# Altium schematic sheet HIGHSPEED_v0_SF2-POWER.SchDoc
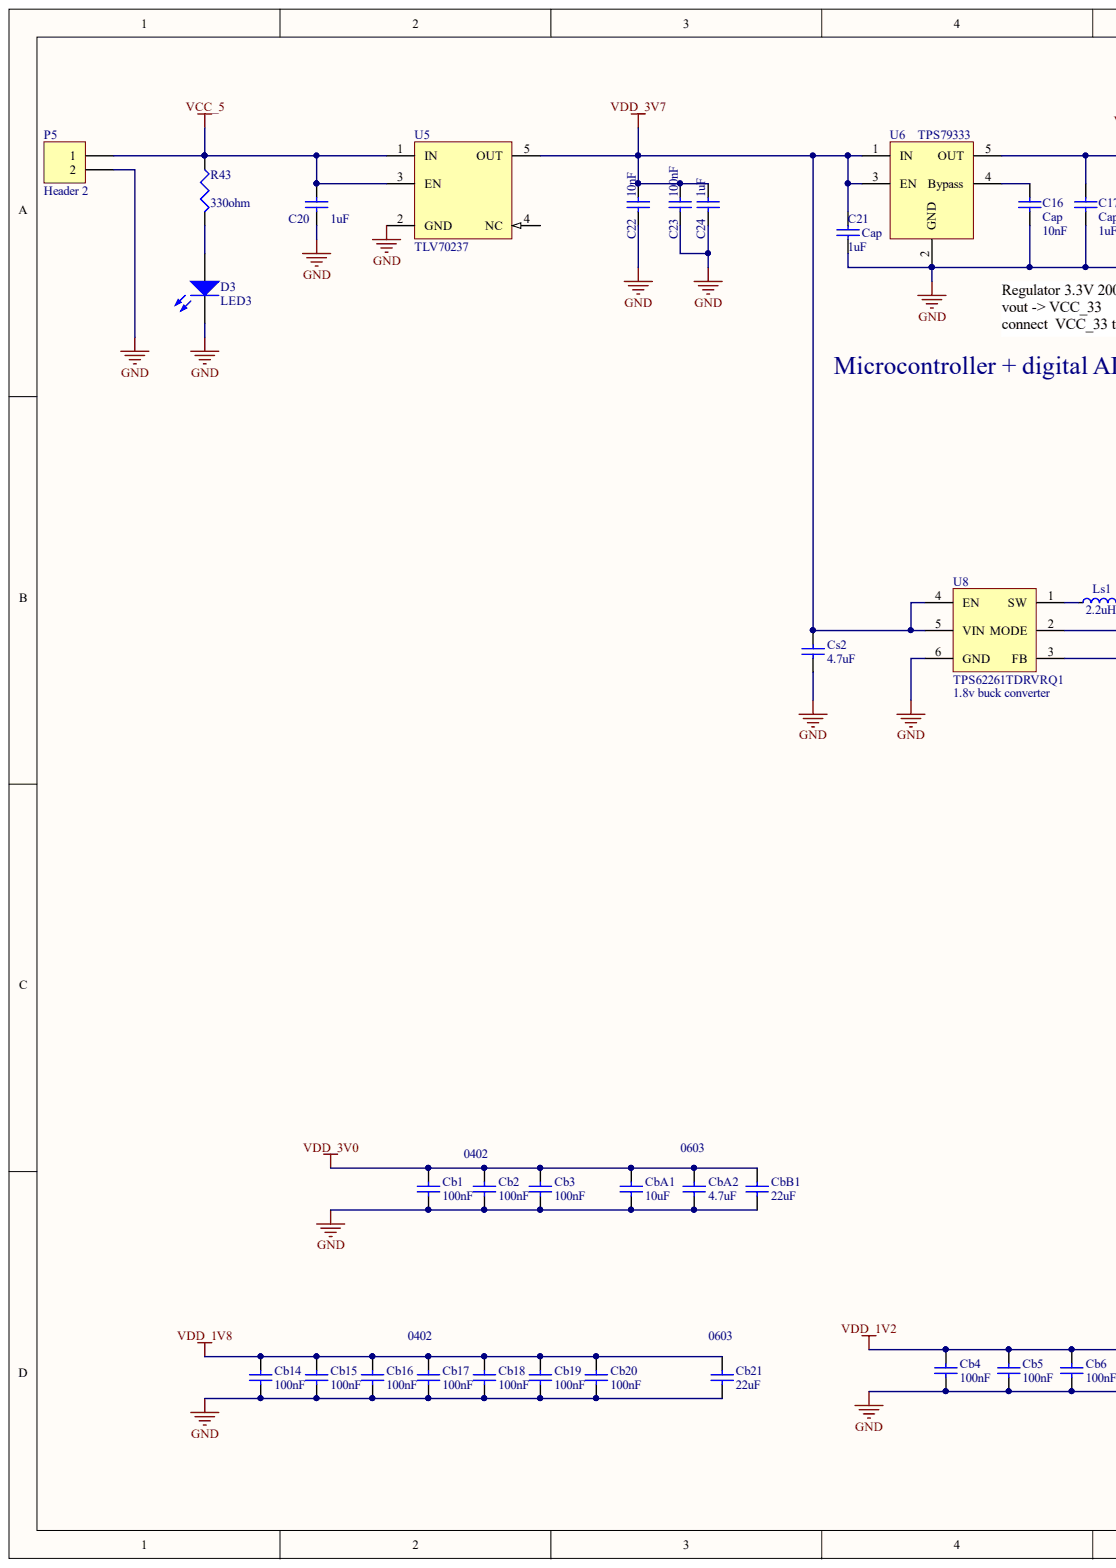
[diagram p0: HIGHSPEED_v0_SF2-POWER.SchDoc, left]
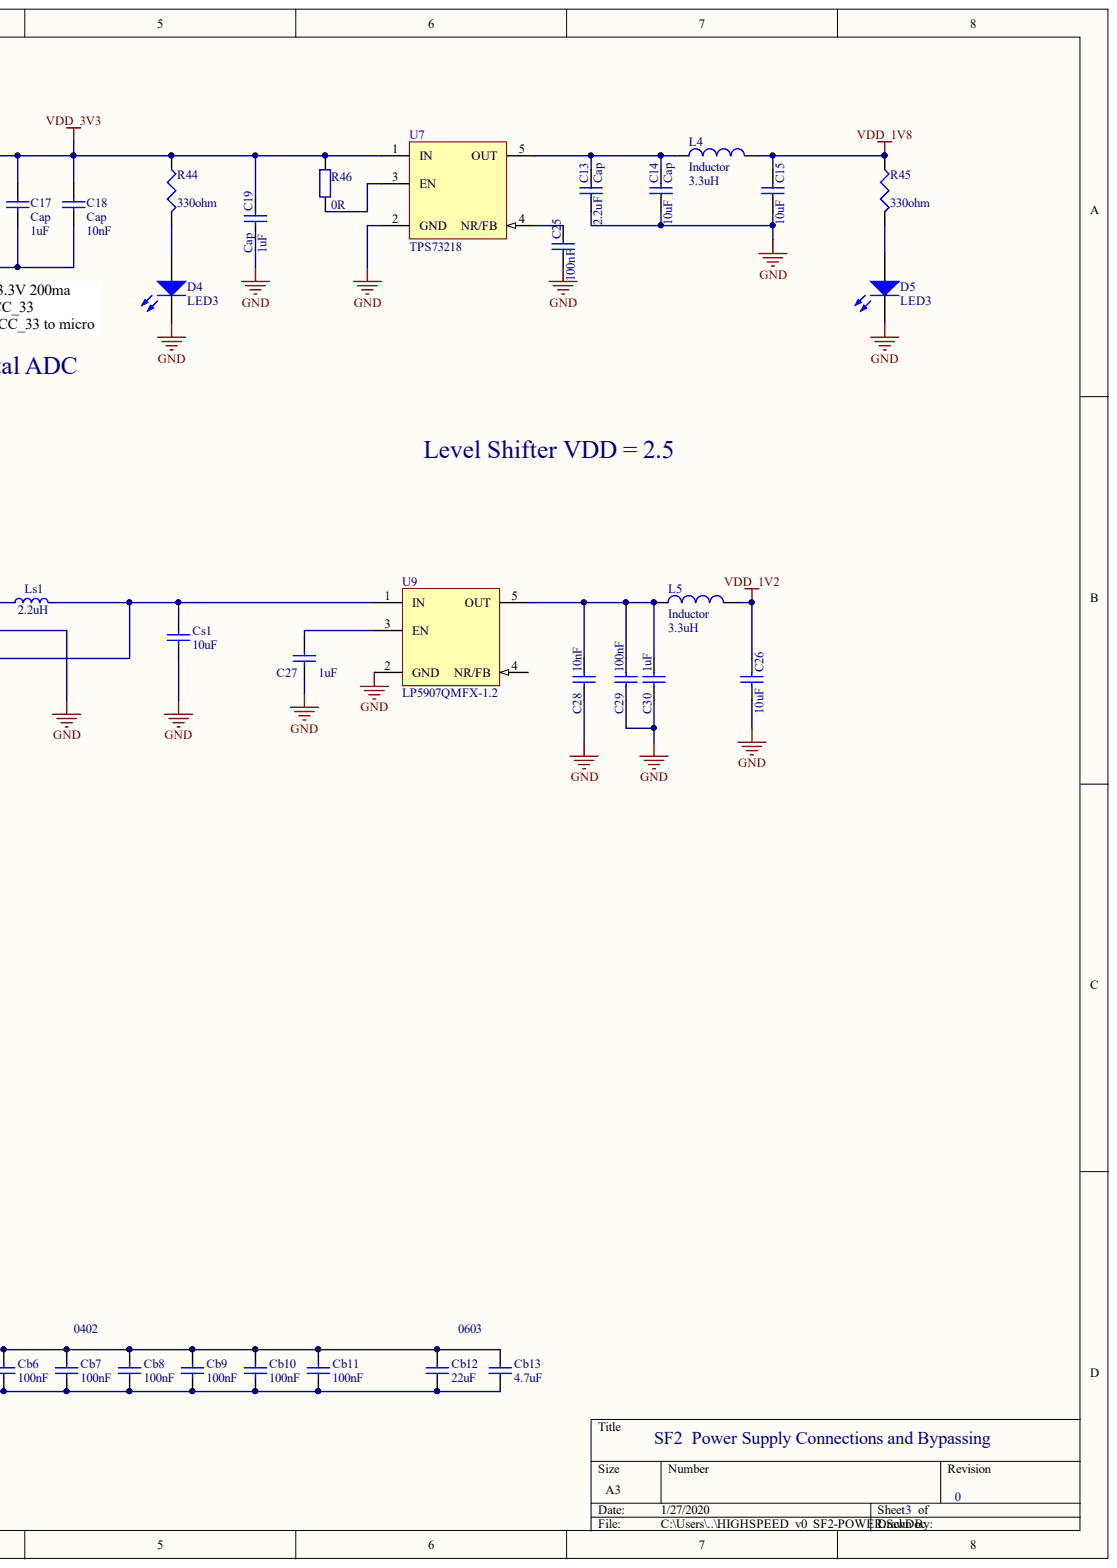
[diagram p1: HIGHSPEED_v0_SF2-POWER.SchDoc, right]

## Parts
C13  Cap  value 2.2uF  [0603]
C14  Cap  value 10uF  [0603]
C15  value 10uF  [0603]  (hidden comment: Cap)
C16  Cap  value 10nF  [cap_0402_R - modified]
C17  Cap  value 1uF  [cap_0402_R - modified]
C18  Cap  value 10nF  [cap_0402_R - modified]
C19  Cap  value 1uF  [0603]
C20  value 1uF  [1608[0603]]  (hidden comment: Cap Semi)
C21  Cap  value 1uF  [cap_0402_R - modified]
C22  value 10nF  [1608[0603]]  (hidden comment: Cap Semi)
C23  value 100nF  [1608[0603]]  (hidden comment: Cap Semi)
C24  value 1uF  [1608[0603]]  (hidden comment: Cap Semi)
C25  value 100nF  [0603]
C26  value 10uF  [0603]  (hidden comment: Cap)
C27  value 1uF  [1608[0603]]  (hidden comment: Cap Semi)
C28  value 10nF  [1608[0603]]  (hidden comment: Cap Semi)
C29  value 100nF  [1608[0603]]  (hidden comment: Cap Semi)
C30  value 1uF  [1608[0603]]  (hidden comment: Cap Semi)
Cb1  value 100nF  [c0402-Murata-GR]  (hidden comment: GRM155R61H104KE19D)
Cb2  value 100nF  [c0402-Murata-GR]  (hidden comment: GRM155R61H104KE19D)
Cb3  value 100nF  [c0402-Murata-GR]  (hidden comment: GRM155R61H104KE19D)
Cb4  value 100nF  [c0402-Murata-GR]  (hidden comment: GRM155R61H104KE19D)
Cb5  value 100nF  [c0402-Murata-GR]  (hidden comment: GRM155R61H104KE19D)
Cb6  value 100nF  [c0402-Murata-GR]  (hidden comment: GRM155R61H104KE19D)
Cb7  value 100nF  [c0402-Murata-GR]  (hidden comment: GRM155R61H104KE19D)
Cb8  value 100nF  [c0402-Murata-GR]  (hidden comment: GRM155R61H104KE19D)
Cb9  value 100nF  [c0402-Murata-GR]  (hidden comment: GRM155R61H104KE19D)
Cb10  value 100nF  [c0402-Murata-GR]  (hidden comment: GRM155R61H104KE19D)
Cb11  value 100nF  [c0402-Murata-GR]  (hidden comment: GRM155R61H104KE19D)
Cb12  value 22uF  [c0603-Murata-GR]  (hidden comment: GRM187R61A226ME15D)
Cb13  value 4.7uF  [c0603-Murata-GR]  (hidden comment: GRM188R6YA475KE15D)
Cb14  value 100nF  [c0402-Murata-GR]  (hidden comment: GRM155R61H104KE19D)
Cb15  value 100nF  [c0402-Murata-GR]  (hidden comment: GRM155R61H104KE19D)
Cb16  value 100nF  [c0402-Murata-GR]  (hidden comment: GRM155R61H104KE19D)
Cb17  value 100nF  [c0402-Murata-GR]  (hidden comment: GRM155R61H104KE19D)
Cb18  value 100nF  [c0402-Murata-GR]  (hidden comment: GRM155R61H104KE19D)
Cb19  value 100nF  [c0402-Murata-GR]  (hidden comment: GRM155R61H104KE19D)
Cb20  value 100nF  [c0402-Murata-GR]  (hidden comment: GRM155R61H104KE19D)
Cb21  value 22uF  [c0603-Murata-GR]  (hidden comment: GRM187R61A226ME15D)
CbA1  value 10uF  [c0603-Murata-GR]  (hidden comment: GRM188R61E106MA73D)
CbA2  value 4.7uF  [c0603-Murata-GR]  (hidden comment: GRM188R6YA475KE15D)
CbB1  value 22uF  [c0603-Murata-GR]  (hidden comment: GRM187R61A226ME15D)
Cs1  value 10uF  [c0603-Murata-GR]  (hidden comment: GRM188R61E106MA73D)
Cs2  value 4.7uF  [c0603-Murata-GR]  (hidden comment: GRM188R6YA475KE15D)
D3  LED3  [0603]
D4  LED3  [0603]
D5  LED3  [0603]
L4  Inductor  value 3.3uH  [1608[0603]]
L5  Inductor  value 3.3uH  [1608[0603]]
Ls1  value 2.2uH  [LEAD_REGULATOR]
P5  Header 2  [HDR1X2]
R43  value 330ohm  [res_0402_R - modified]  (hidden comment: Res3)
R44  value 330ohm  [res_0402_R - modified]  (hidden comment: Res3)
R45  value 330ohm  [res_0402_R - modified]  (hidden comment: Res3)
R46  value 0R  [0603]
U5  TLV70237  [SOT23__DDC__(R - PDSO-  G5)]
U6  TPS79333  [SOT_23__DBV_(R - PDSO - G5)]
U7  TPS73218  [SOT23__DDC__(R - PDSO-  G5)]
U8  TPS62261TDRVRQ1  Note: 1.8v buck converter  [TPS_PACKAGE_novia]
U9  LP5907QMFX-1.2  [SOT23__DDC__(R - PDSO-  G5)]

## Nets
GND: C13.2 C14.2 C15.2 C16.1 C17.2 C18.1 C19.2 C20.1 C21.1 C22.1 C23.1 C24.1 C25.2 C26.2 C27.1 C28.1 C29.1 C30.1 Cb1.1 Cb2.1 Cb3.1 Cb4.1 Cb5.1 Cb6.1 Cb7.1 Cb8.1 Cb9.1 Cb10.1 Cb11.1 Cb12.1 Cb13.1 Cb14.1 Cb15.1 Cb16.1 Cb17.1 Cb18.1 Cb19.1 Cb20.1 Cb21.1 CbA1.1 CbA2.1 CbB1.1 Cs1.1 Cs2.1 D3.2 D4.2 D5.2 P5.2 U5.2(GND) U6.2(GND) U7.2(GND) U8.2(MODE) U8.6(GND) U8.7 U9.2(GND)
VCC_5: C20.2 P5.1 R43.1 U5.1(IN) U5.3(EN)
VDD_1V2: C26.1 Cb4.2 Cb5.2 Cb6.2 Cb7.2 Cb8.2 Cb9.2 Cb10.2 Cb11.2 Cb12.2 Cb13.2 L5.2
VDD_1V8: C15.1 Cb14.2 Cb15.2 Cb16.2 Cb17.2 Cb18.2 Cb19.2 Cb20.2 Cb21.2 L4.2 R45.1
VDD_3V0: Cb1.2 Cb2.2 Cb3.2 CbA1.2 CbA2.2 CbB1.2
VDD_3V3: C17.1 C18.2 C19.1 R44.1 R46.2 U6.5(OUT) U7.1(IN)
VDD_3V7: C21.2 C22.2 C23.2 C24.2 Cs2.2 U5.5(OUT) U6.1(IN) U6.3(EN) U8.4(EN) U8.5(VIN)
NetC13_1: C13.1 C14.1 L4.1 U7.5(OUT)
NetC16_2: C16.2 U6.4(Bypass)
NetC25_1: C25.1 U7.4(NR/FB)
NetC27_2: C27.2 U9.3(EN)
NetC28_2: C28.2 C29.2 C30.2 L5.1 U9.5(OUT)
NetCs1_2: Cs1.2 Ls1.1 U8.3(FB) U9.1(IN)
NetD3_1: D3.1 R43.2
NetD4_1: D4.1 R44.2
NetD5_1: D5.1 R45.2
NetLs1_2: Ls1.2 U8.1(SW)
NetR46_1: R46.1 U7.3(EN)

Not connected: U5 4(NC); U9 4(NR/FB)

## Library data of the parts
C13-C19 C21 C25 C26 = Cap: Capacitor; Component Kind: Standard; LatestRevisionDate: 17-Jul-2002; LatestRevisionNote: Re-released for DXP Platform.; Library Name: Miscellaneous Devices.IntLib; MOUNT: M; PackageDescription: Radial Cap, Thru-Hole; 2 Leads; 0.3 in Pin Spacing; PackageReference: RAD-0.3; Published: 8-Jun-2000; Signal Integrity: Cap; Simulation: CAP; Publisher: Altium Limited
C20 C22-C24 C27-C30 = Cap Semi: Capacitor; Component Kind: Standard; LatestRevisionDate: 29-May-2009; LatestRevisionNote: IPC-7351 Footprint Added.; Library Name: Miscellaneous Devices.IntLib; MOUNT: M; PackageDescription: Chip Capacitor; PackageReference: C1206; Published: 8-Jun-2000; Signal Integrity: Cap Semi; Simulation: CAP; Publisher: Altium Limited
Cb1-Cb11 Cb14-Cb20 = Cap: CAP CER 0.1UF 50V X5R 0402; Manufacturer: Murata; Component Kind: Standard; Library Name: Custom.IntLib; Manufacturer PN: GRM155R61H104KE19D; Signal Integrity: Cap; Simulation: CAP; Supplier: Digikey; Supplier PN: 490-11917-1-ND
Cb12 Cb21 CbB1 = Cap: CAP CER 22UF 10V X5R 0603; Manufacturer: Murata; Note: 10V X5R 20%; Component Kind: Standard; Library Name: Custom.SchLib; Manufacturer PN: GRM187R61A226ME15D; Signal Integrity: Cap; Simulation: CAP; Supplier: Digikey; Supplier PN: 490-13243-1-ND
Cb13 CbA2 Cs2 = Cap: CAP CER 4.7UF 35V X5R 0603; Manufacturer: Murata; Component Kind: Standard; Library Name: Custom.IntLib; Manufacturer PN: GRM188R6YA475KE15D; Signal Integrity: Cap; Simulation: CAP; Supplier: Digikey; Supplier PN: 490-7205-1-ND
CbA1 Cs1 = Cap: CAP CER 10UF 25V X5R 0603 20%; Manufacturer: Murata; Component Kind: Standard; Library Name: Custom.SchLib; Manufacturer PN: GRM188R61E106MA73D; Signal Integrity: Cap; Simulation: CAP; Supplier: Digikey; Supplier PN: 490-7202-1-ND
D3-D5 = LED3: Typical BLUE SiC LED; Component Kind: Standard; DatasheetVersion: 16-Apr-2002; LatestRevisionDate: 17-Dec-2003; LatestRevisionNote: PCB Footprint replaced.; Library Name: Miscellaneous Devices.IntLib; PackageDescription: SM LED; 2 C-Bend Leads; Body 3.5 x 2.8 x 1.9 mm, inc leads (LxWxH typ); PackageReference: 3.5X2.8X1.9; PackageVersion: 16-Apr-2002; Published: 8-Jun-2000; Signal Integrity: LED; Simulation: LED3; Publisher: Altium Limited
L4 L5 = Inductor: Code_IEC: 1005; Code_JEITA: 0402; Component Kind: Standard; Description: Inductor; LatestRevisionDate: 29-May-2009; LatestRevisionNote: IPC-7351 Footprint Added.; Library Name: Miscellaneous Devices.IntLib; MOUNT: M; PackageDescription: Chip Inductor; PackageReference: 0402-A; Published: 8-Jun-2000; Signal Integrity: Inductor; Simulation: INDUCTOR; Publisher: Altium Limited
Ls1 = LEAD_REGUALTOR: FIXED IND 2.2UH 1A 120 MOHM SMD; Manufacturer: Murata; Manufacturer PN: LQM2HPN2R2MJ0L; Component Kind: Standard; Library Name: CM.SchLib; Supplier: Digikey; Supplier PN: 490-6700-6-ND
P5 = Header 2: Header, 2-Pin; Component Kind: Standard; LatestRevisionDate: 17-Jul-2002; LatestRevisionNote: Re-released for DXP Platform.; Library Name: Miscellaneous Connectors.IntLib; Signal Integrity: Connector; Publisher: Altium Limited
R43-R45 = Res3: Resistor; Component Kind: Standard; LatestRevisionDate: 29-May-2009; LatestRevisionNote: IPC-7351 Footprint Added.; Library Name: Miscellaneous Devices.IntLib; PackageDescription: Chip Resistor; PackageReference: J1-0603; Published: 8-Jun-2000; Signal Integrity: Res; Simulation: RESISTOR; Publisher: Altium Limited
R46 = Res2: Resistor; Component Kind: Standard; LatestRevisionDate: 17-Jul-2002; LatestRevisionNote: Re-released for DXP Platform.; Library Name: Miscellaneous Devices.IntLib; MOUNT: M; PackageDescription: Axial Device, Thru-Hole; 2 Leads; 0.4 in Pin Spacing; PackageReference: AXIAL-0.4; Published: 8-Jun-2000; Signal Integrity: Res; Simulation: RESISTOR; Publisher: Altium Limited
U5 = TLV70237: Linear Regulator; Component Kind: Standard; Library Name: Demo_Board_library_SCH.SchLib; MOUNT: M
U6 = TPS79333: Regulator; Component Kind: Standard; Library Name: Demo_Board_library_SCH.SchLib; MOUNT: M
U7 = TPS73218: Linear Regulator; Component Kind: Standard; Library Name: Demo_Board_library_SCH.SchLib
U8 = TPS62261: IC REG BUCK 1.8V 0.6A SYNC 6SON; Manufacturer: TI; Component Kind: Standard; Library Name: CM.SchLib; Manufacturer PN: TPS62261TDRVRQ1; Supplier: Digikey; Supplier PN: 296-25729-1-ND
U9 = LP5907QMFX-1.2: Linear Regulator; Component Kind: Standard; Library Name: Demo_Board_library_SCH.SchLib
